# Altium schematic sheet 16-ECP5_FPGA_1.SchDoc
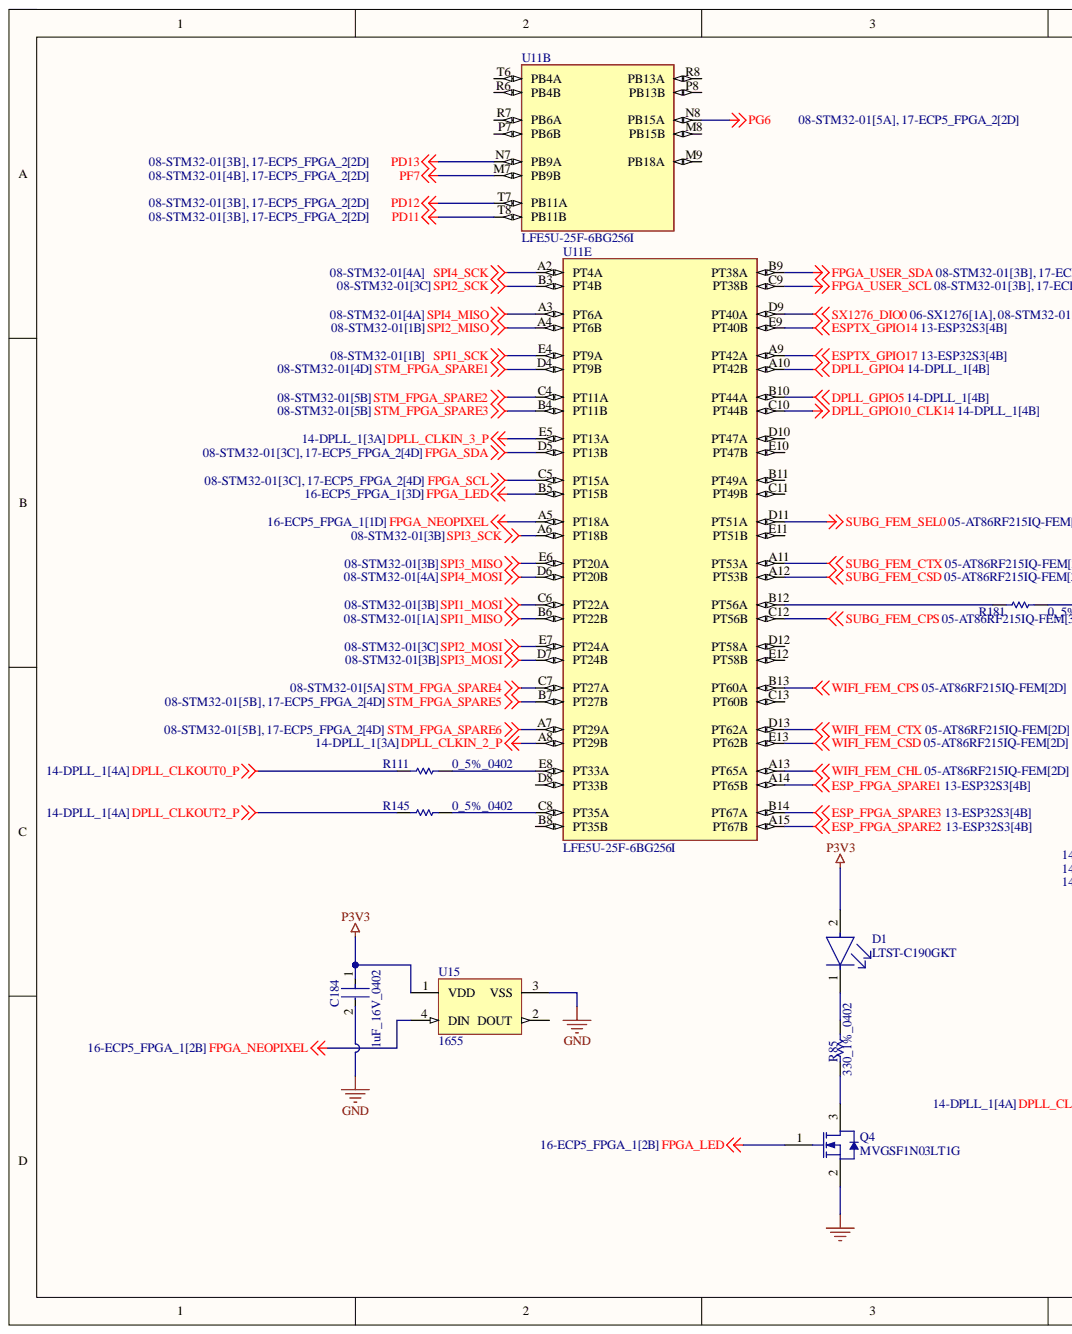
[diagram p0: 16-ECP5_FPGA_1.SchDoc, left]
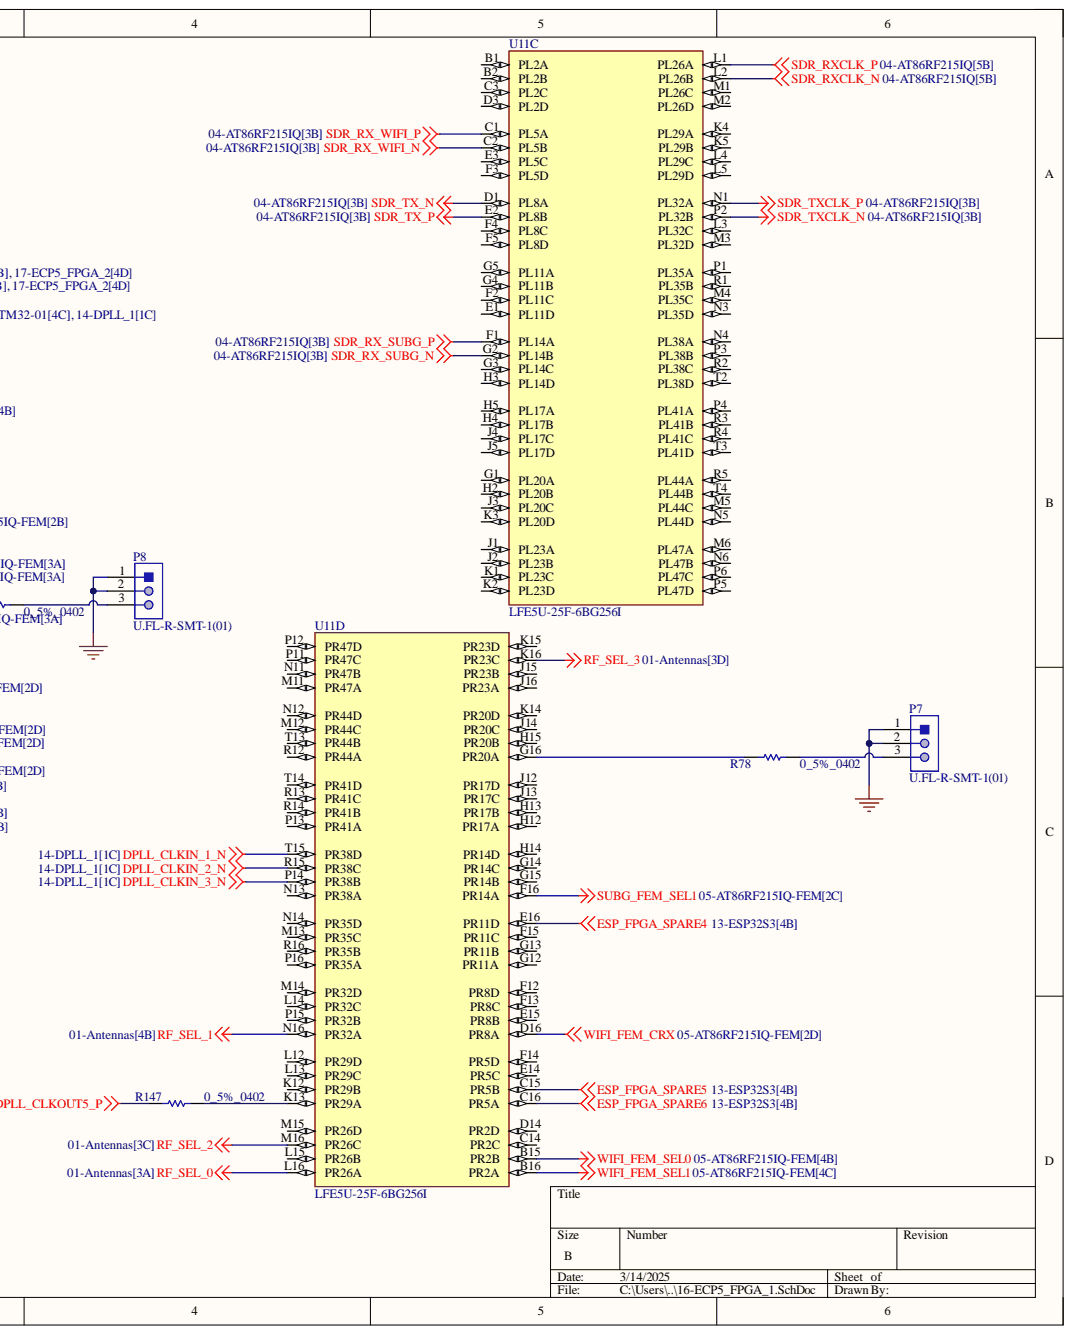
[diagram p1: 16-ECP5_FPGA_1.SchDoc, right]

## Parts
C184  1uF_16V_0402  [FP-0402-L_1_0_0_05-W_0_5-IPC_A]
D1  LTST-C190GKT  [FP-LTST-C190GKT-MFG]
P7  U.FL-R-SMT-1(01)  [HRS-U.FL-R-SMT-3_V]
P8  U.FL-R-SMT-1(01)  [HRS-U.FL-R-SMT-3_V]
Q4  MVGSF1N03LT1G  [ONSC-SOT-23-3-318-08_V]
R78  0_5%_0402  [RESC1005X40X25NL05T10]
R85  330_1%_0402  [RESC1005X40X25NL10T10]
R111  0_5%_0402  [RESC1005X40X25NL05T10]
R145  0_5%_0402  [RESC1005X40X25NL05T10]
R147  0_5%_0402  [RESC1005X40X25NL05T10]
R181  0_5%_0402  [RESC1005X40X25NL05T10]
U11  LFE5U-25F-6BG256I  [FP-LFE5U-25F-6BG256I-MFG]  (parts B,C,D,E)
U15  1655  [ADA-RGB-1655_V]

## Nets
DPLL_CLKIN_1_N: U11.T15(PR38D)
DPLL_CLKIN_2_N: U11.R15(PR38C)
DPLL_CLKIN_2_P: U11.A8(PT29B)
DPLL_CLKIN_3_N: U11.P14(PR38B)
DPLL_CLKIN_3_P: U11.E5(PT13A)
DPLL_CLKOUT0_P: R111.1
DPLL_CLKOUT2_P: R145.1
DPLL_CLKOUT5_P: R147.1
DPLL_GPIO4: U11.A10(PT42B)
DPLL_GPIO5: U11.B10(PT44A)
DPLL_GPIO10_CLK14: U11.C10(PT44B)
ESP_FPGA_SPARE1: U11.A14(PT65B)
ESP_FPGA_SPARE2: U11.A15(PT67B)
ESP_FPGA_SPARE3: U11.B14(PT67A)
ESP_FPGA_SPARE4: U11.E16(PR11D)
ESP_FPGA_SPARE5: U11.C15(PR5B)
ESP_FPGA_SPARE6: U11.C16(PR5A)
ESPTX_GPIO14: U11.E9(PT40B)
ESPTX_GPIO17: U11.A9(PT42A)
FPGA_LED: Q4.1 U11.B5(PT15B)
FPGA_NEOPIXEL: U11.A5(PT18A) U15.4(DIN)
FPGA_SCL: U11.C5(PT15A)
FPGA_SDA: U11.D5(PT13B)
FPGA_USER_SCL: U11.C9(PT38B)
FPGA_USER_SDA: U11.B9(PT38A)
GND: C184.2 P7.1 P7.2 P8.1 P8.2 Q4.2 U15.3(VSS)
P3V3: C184.1 D1.2 U15.1(VDD)
PD11: U11.T8(PB11B)
PD12: U11.T7(PB11A)
PD13: U11.N7(PB9A)
PF7: U11.M7(PB9B)
PG6: U11.N8(PB15A)
RF_SEL_0: U11.L16(PR26A)
RF_SEL_1: U11.N16(PR32A)
RF_SEL_2: U11.M16(PR26C)
RF_SEL_3: U11.K16(PR23C)
SDR_RX_SUBG_N: U11.G2(PL14B)
SDR_RX_SUBG_P: U11.F1(PL14A)
SDR_RX_WIFI_N: U11.C2(PL5B)
SDR_RX_WIFI_P: U11.C1(PL5A)
SDR_RXCLK_N: U11.L2(PL26B)
SDR_RXCLK_P: U11.L1(PL26A)
SDR_TX_N: U11.D1(PL8A)
SDR_TX_P: U11.E2(PL8B)
SDR_TXCLK_N: U11.P2(PL32B)
SDR_TXCLK_P: U11.N1(PL32A)
SPI1_MISO: U11.B6(PT22B)
SPI1_MOSI: U11.C6(PT22A)
SPI1_SCK: U11.E4(PT9A)
SPI2_MISO: U11.A4(PT6B)
SPI2_MOSI: U11.E7(PT24A)
SPI2_SCK: U11.B3(PT4B)
SPI3_MISO: U11.E6(PT20A)
SPI3_MOSI: U11.D7(PT24B)
SPI3_SCK: U11.A6(PT18B)
SPI4_MISO: U11.A3(PT6A)
SPI4_MOSI: U11.D6(PT20B)
SPI4_SCK: U11.A2(PT4A)
STM_FPGA_SPARE1: U11.D4(PT9B)
STM_FPGA_SPARE2: U11.C4(PT11A)
STM_FPGA_SPARE3: U11.B4(PT11B)
STM_FPGA_SPARE4: U11.C7(PT27A)
STM_FPGA_SPARE5: U11.B7(PT27B)
STM_FPGA_SPARE6: U11.A7(PT29A)
SUBG_FEM_CPS: U11.C12(PT56B)
SUBG_FEM_CSD: U11.A12(PT53B)
SUBG_FEM_CTX: U11.A11(PT53A)
SUBG_FEM_SEL0: U11.D11(PT51A)
SUBG_FEM_SEL1: U11.F16(PR14A)
SX1276_DIO0: U11.D9(PT40A)
WIFI_FEM_CHL: U11.A13(PT65A)
WIFI_FEM_CPS: U11.B13(PT60A)
WIFI_FEM_CRX: U11.D16(PR8A)
WIFI_FEM_CSD: U11.E13(PT62B)
WIFI_FEM_CTX: U11.D13(PT62A)
WIFI_FEM_SEL0: U11.B15(PR2B)
WIFI_FEM_SEL1: U11.B16(PR2A)
NetD1_1: D1.1 R85.2
NetP7_3: P7.3 R78.2
NetP8_3: P8.3 R181.2
NetQ4_3: Q4.3 R85.1
NetR78_1: R78.1 U11.G16(PR20A)
NetR111_2: R111.2 U11.E8(PT33A)
NetR145_2: R145.2 U11.C8(PT35A)
NetR147_2: R147.2 U11.K13(PR29A)
NetR181_1: R181.1 U11.B12(PT56A)

Not connected: U11 B1(PL2A) B2(PL2B) B8(PT35B) B11(PT49A) C3(PL2C) C11(PT49B) C13(PT60B) C14(PR2C) D3(PL2D) D8(PT33B) D10(PT47A) D12(PT58A) D14(PR2D) E1(PL11D) E3(PL5C) E10(PT47B) E11(PT51B) E12(PT58B) E14(PR5C) E15(PR8B) F2(PL11C) F3(PL5D) F4(PL8C) F5(PL8D) F12(PR8D) F13(PR8C) F14(PR5D) F15(PR11C) G1(PL20A) G3(PL14C) G4(PL11B) G5(PL11A) G12(PR11A) G13(PR11B) G14(PR14C) G15(PR14B) H2(PL20B) H3(PL14D) H4(PL17B) H5(PL17A) H12(PR17A) H13(PR17B) H14(PR14D) H15(PR20B) J1(PL23A) J2(PL23B) J3(PL20C) J4(PL17C) J5(PL17D) J12(PR17D) J13(PR17C) J14(PR20C) J15(PR23B) J16(PR23A) K1(PL23C) K2(PL23D) K3(PL20D) K4(PL29A) K5(PL29B) K12(PR29B) K14(PR20D) K15(PR23D) L3(PL32C) L4(PL29C) L5(PL29D) L12(PR29D) L13(PR29C) L14(PR32C) L15(PR26B) M1(PL26C) M2(PL26D) M3(PL32D) M4(PL35C) M5(PL44C) M6(PL47A) M8(PB15B) M9(PB18A) M11(PR47A) M12(PR44C) M13(PR35C) M14(PR32D) M15(PR26D) N3(PL35D) N4(PL38A) N5(PL44D) N6(PL47B) N11(PR47B) N12(PR44D) N13(PR38A) N14(PR35D) P1(PL35A) P3(PL38B) P4(PL41A) P5(PL47D) P6(PL47C) P7(PB6B) P8(PB13B) P11(PR47C) P12(PR47D) P13(PR41A) P15(PR32B) P16(PR35A) R1(PL35B) R2(PL38C) R3(PL41B) R4(PL41C) R5(PL44A) R6(PB4B) R7(PB6A) R8(PB13A) R12(PR44A) R13(PR41C) R14(PR41B) R16(PR35B) T2(PL38D) T3(PL41D) T4(PL44B) T6(PB4A) T13(PR44B) T14(PR41D); U15 2(DOUT)

## Library data of the parts
C184 = CMP-14477-000104-2: None; Capacitance: 1uF; Dielectric: X5R; Tolerance: 10%; Voltage Rating: 16V; Case Code (Imperial): 0402; Case Code (Metric): 1005; Case/Package: 0402; Component Kind: Standard; Construction: Flat; Depth: 0.5mm; Halogen Free: Halogen Free; Height: 0.5mm; Length: 1mm; Library Name: Altium Content Vault; Max Operating Temperature: 85°C; Min Operating Temperature: -55°C; Mount: Surface Mount; Number of Pins: 2; Package Quantity: 1; Packaging: Tape and Reel; Radiation Hardening: No; RoHS Compliant: Yes; Series: M; Termination: SMD/SMT; Thickness: 0.022inch; Voltage Rating (DC): 16V; Width: 0.02inch
D1 = CMP-22017-000005-2: LED GREEN CLEAR CHIP SMD; Dominant Wavelength: 569nm; Forward Current: 30mA; Forward Voltage: 2.1V; Lens Color: Colorless; Luminous Intensity: 6mcd; Number of Elements: 1; Power Dissipation: 100mW; Reverse Voltage: 5V; Test Current: 10mA; Viewing Angle: 130°; Voltage: 2V; Wavelength: 565nm; Case Code (Imperial): 0603; Case Code (Metric): 1608; Case/Package: 0603; Color: Green; Component Kind: Standard; Depth: 0.8mm; Height: 0.8mm; Illumination Color: Green; Lead Free: Lead Free; Length: 1.6mm; Lens Style: Clear; Lens Transparency: Clear; Library Name: Altium Content Vault; Max Operating Temperature: 85°C; Min Operating Temperature: -55°C; Mount: Surface Mount; Number of LEDs: 1; Number of Pins: 2; Package Quantity: 1; Packaging: Tape and Reel; Power Rating: 100mW; Radiation Hardening: No; Width: 0.8mm
P7 P8 = CMP-2000-05153-1: Ultra Small Coaxial Connector, 6 GHz, -40 to 90 degC, 3-Pin SMD, RoHS, Bulk; Manufacturer: Hirose Electric; Body Finish: Silver; Body Material: PhosphorBronze; Center Contact Plating: Gold; Connector Style: U.FL; Connector Type: Jack,MalePin; Contact Termination: Solder; Dielectric Material: LiquidCrystalPolymer(LCP); Dynamic Catalog: U.FLSeries; Featured Product: HiroseU.FLSeries; Frequency - Max: 6GHz; Impedance: 50Ohm; Minimum Quantity: UltraMiniatureCoaxial; Other Names: H122041  U.FL-R-SMT-1(01)-ND; Category: Connectors,Interconnects; Center Contact Material: PhosphorBronze; Component Kind: Standard; Datasheet URL: https://datasheet.ciiva.com/26945/getdatasheetpartid-475239-26945414.pdf; Datasheet Version: Feb-09; Family: CoaxialConnectors(RF); Fastening Type: Snap-On; Housing Color: Silver; Library Name: Altium Content Vault; Manufacturer URL: https://www.hirose.com; Mounting Type: SurfaceMount; Online Catalog: U.FLSeries; Operating Temperature: -40degC~90degC; Package Description: 3-Pin Surface Mount Device, Body 2.6 x 2.6 mm; Package Reference: U.FL-R-SMT; Packaging: Bulk; Quantity Available: Bulk; Series: U.FL; Shield Termination: Solder; Standard Package: 100; Unit PriceUSD: U.FL
Q4 = CMP-1058-00779-1: Power MOSFET, 30 V, 2.1 A, Single N-Channel, 3-Pin SOT-23, Pb-Free, Tape and Reel; Manufacturer: On Semiconductor; Channel Polarity: N Channel; Ciss Typ (pF): 140; Coss Typ (pF): 100; Crss Typ (pF): 40; ID Max (A): 2.1; V(BR)DSS Min (V): 30; VGS Max (V): 20; VGS(th) Max (V): 2.4; Component Kind: Standard; ComponentLink1Description: Manufacturer URL; ComponentLink1URL: http://www.onsemi.com/; ComponentLink2Description: Datasheet; ComponentLink2URL: http://www.onsemi.cn/pub_link/Collateral/MGSF1N03LT1-D.PDF; ComponentLink3Description: Package Specification; ComponentLink3URL: http://www.onsemi.cn/pub_link/Collateral/318-08.PDF; Configuration: Single; DatasheetVersion: Rev. 10; Library Name: Altium Content Vault; Mounting Technology: Surface Mount; Package Type: SOT-23-3; PackageDescription: 3-Pin Small Outline Transistor, Body 2.92 x 1.3 mm, Pitch 0.95 mm; PackageReference: SOT-23-3-318-08; PackageVersion: Rev. AP, 11/2009; Packing: Tape and Reel; PartNumber: MVGSF1N03LT1G; RoHS: Pb-Free
R78 R111 R145 R147 R181 = CMP-2002-06457-1: Manufacturer: Panasonic; Part Number: ERJ-U020R00X; Component Kind: Standard; Library Name: Altium Content Vault
R85 = CMP-2002-05853-1: Manufacturer: Panasonic; Part Number: ERA-2AED331X; Component Kind: Standard; Library Name: Altium Content Vault
U11 = CMP-17932-000009-1: IC FPGA 197 I/O 256CABGA; Number of I/Os: 197; Number of Logic Blocks (LABs): 6000; Number of Logic Elements/Cells: 24000; RAM Size: 1032192b; Component Kind: Standard; Library Name: Altium Content Vault; Max Operating Temperature: 100°C; Min Operating Temperature: -40°C; Mount: Surface Mount; Number of Pins: 256; RoHS Compliant: Yes
U15 = CMP-2000-05026-1: Intelligent Control LED Integrated Light Source, 3.5 to 5.3 V, -25 to 80 degC, 4-Pin SMD, RoHS, Tape and Reel; Manufacturer: Adafruit-Industries-LLC; Configuration: Discrete; Number of LEDs: 10; Utilized LED: WS2812(5050); Category: Optoelectronics; Color: Red,Green,Blue(RGB); Component Kind: Standard; Controller Type: -; Datasheet URL: http://datasheet.octopart.com/ADA1655-Adafruit-Industries-datasheet-32028139.pdf; Datasheet Version: May-13; Family: Addressable,Specialty; Interface: -; Library Name: Altium Content Vault; Manufacturer URL: https://www.adafruit.com/; Mounting Type: SurfaceMount; Package Description: 4-Pin Surface Mount Device, Body 5 x 5 mm; Package Reference: 1655; Series: Neopixel; Size / Dimension: 5.00mmLx5.00mmW
